# Altium schematic sheet ADC Module.SchDoc
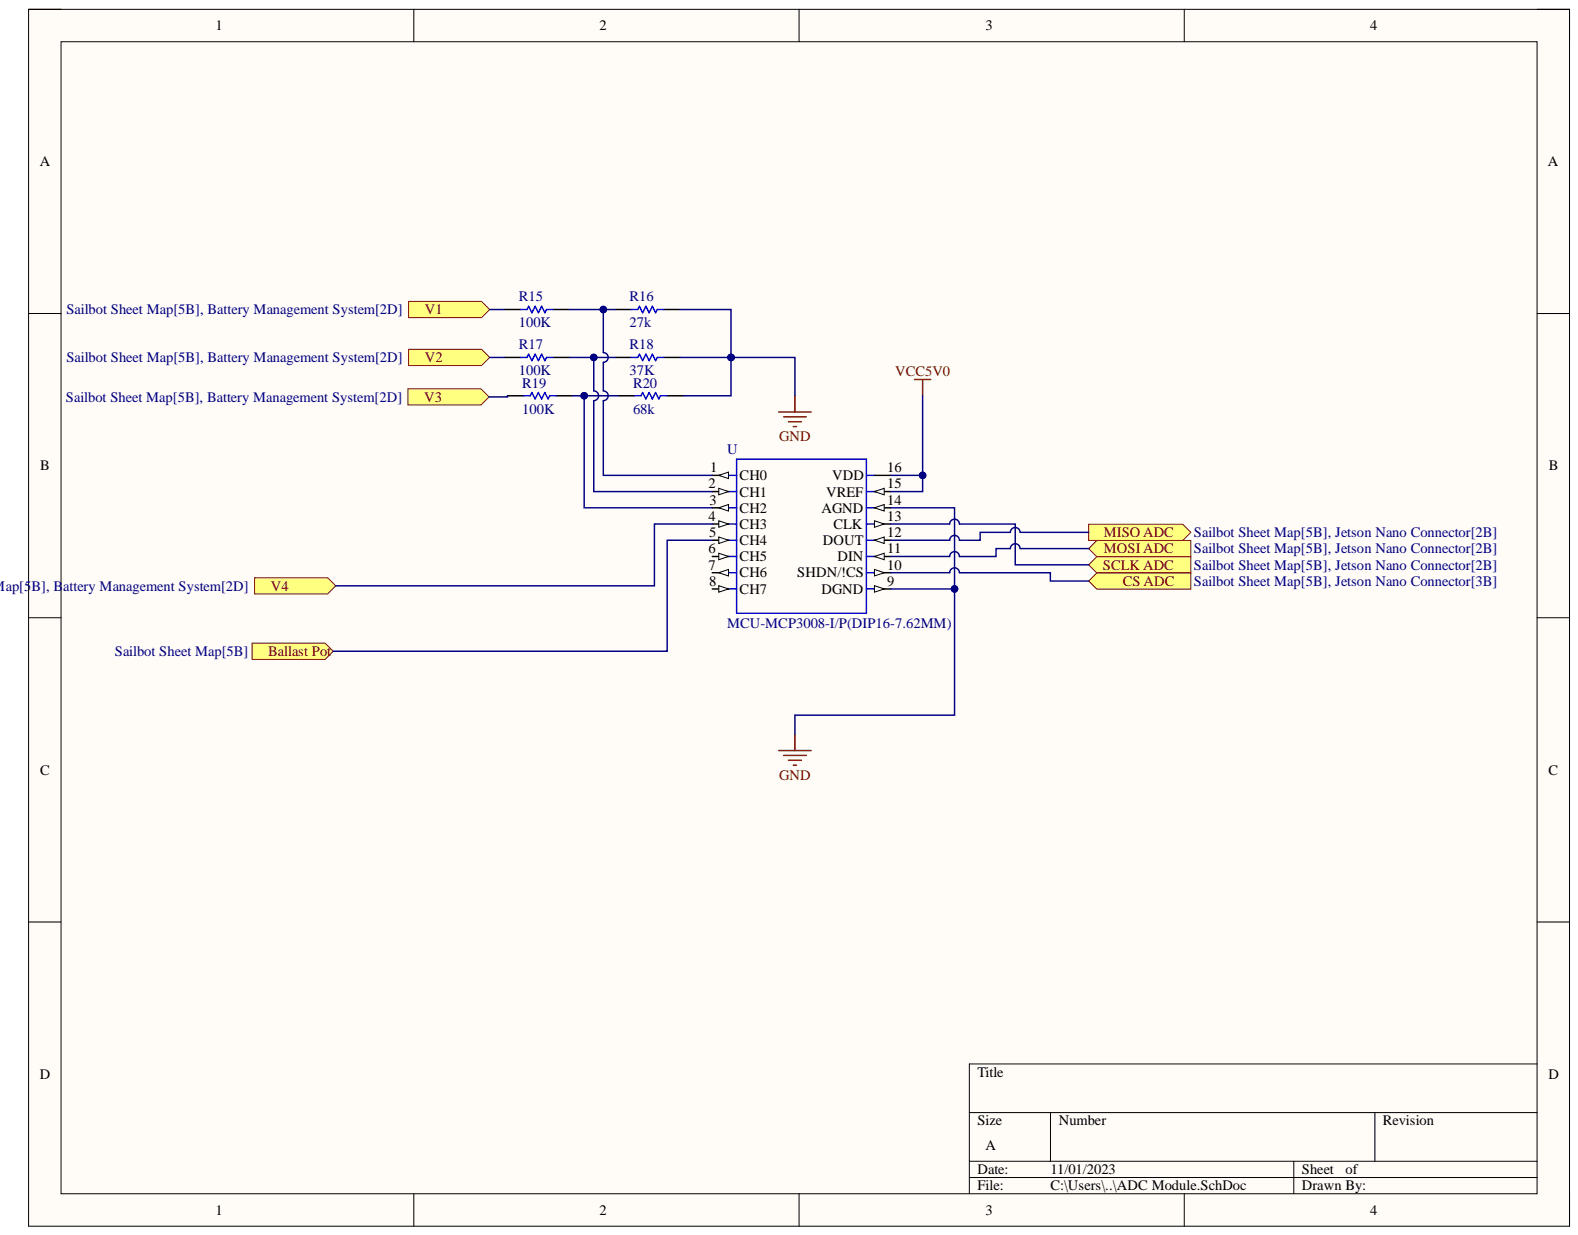
[diagram p0: ADC Module.SchDoc]

## Parts
R15  value 100K  (hidden comment: Resistor)
R16  value 27k  (hidden comment: Resistor)
R17  value 100K  (hidden comment: Resistor)
R18  value 37K  (hidden comment: Resistor)
R19  value 100K  (hidden comment: Resistor)
R20  value 68k  (hidden comment: Resistor)
U  MCU-MCP3008-I/P(DIP16-7.62MM)  [DIP16-2.54-19.2X7.62MM]

## Ports
Ballast Pot, CS ADC, MISO ADC, MOSI ADC, SCLK ADC, V1, V2, V3, V4

## Nets
GND: R16.1 R18.1 R20.2 U.9(DGND) U.14(AGND)
VCC5V0: U.15(VREF) U.16(VDD)
Ballast Pot: U.5(CH4) ; port Ballast Pot
CS ADC: U.10(SHDN/!CS) ; port CS ADC
MISO ADC: U.12(DOUT) ; port MISO ADC
MOSI ADC: U.11(DIN) ; port MOSI ADC
SCLK ADC: U.13(CLK) ; port SCLK ADC
V1: R15.2 ; port V1
V2: R17.2 ; port V2
V3: R19.2 ; port V3
V4: U.4(CH3) ; port V4
NetR15_1: R15.1 R16.2 U.1(CH0)
NetR17_1: R17.1 R18.2 U.2(CH1)
NetR19_1: R19.1 R20.1 U.3(CH2)
NetU_6: U.6(CH5)
NetU_7: U.7(CH6)
NetU_8: U.8(CH7)

## Library data of the parts
R15-R20 = Resistor: SimulationGenericComponent: True; TC1: 0; Component Kind: Standard; Description: Resistor; Library Name: Simulation Generic Components.IntLib; Simulation: R_Resistor_Default; TC2: 0
U = MCU-MCP3008-I/P(DIP16-7.62MM): 10 Bit Analog to Digital Converter 4, 8 Input 1 SAR 16-PDIP; MF: Microchip; Availability: Unavailable; Component Kind: Standard; MP: MCP3008-I/P; Package: DIP-16 Microchip; Price: None; SnapEDA_Link: https://www.snapeda.com/parts/MCP3008-I/P/Microchip/view-part/43628/?ref=snap; Library Name: Sailbot Library.SchLib
